# Altium schematic sheet 06_POWER_4.SchDoc(06_POWER_4)
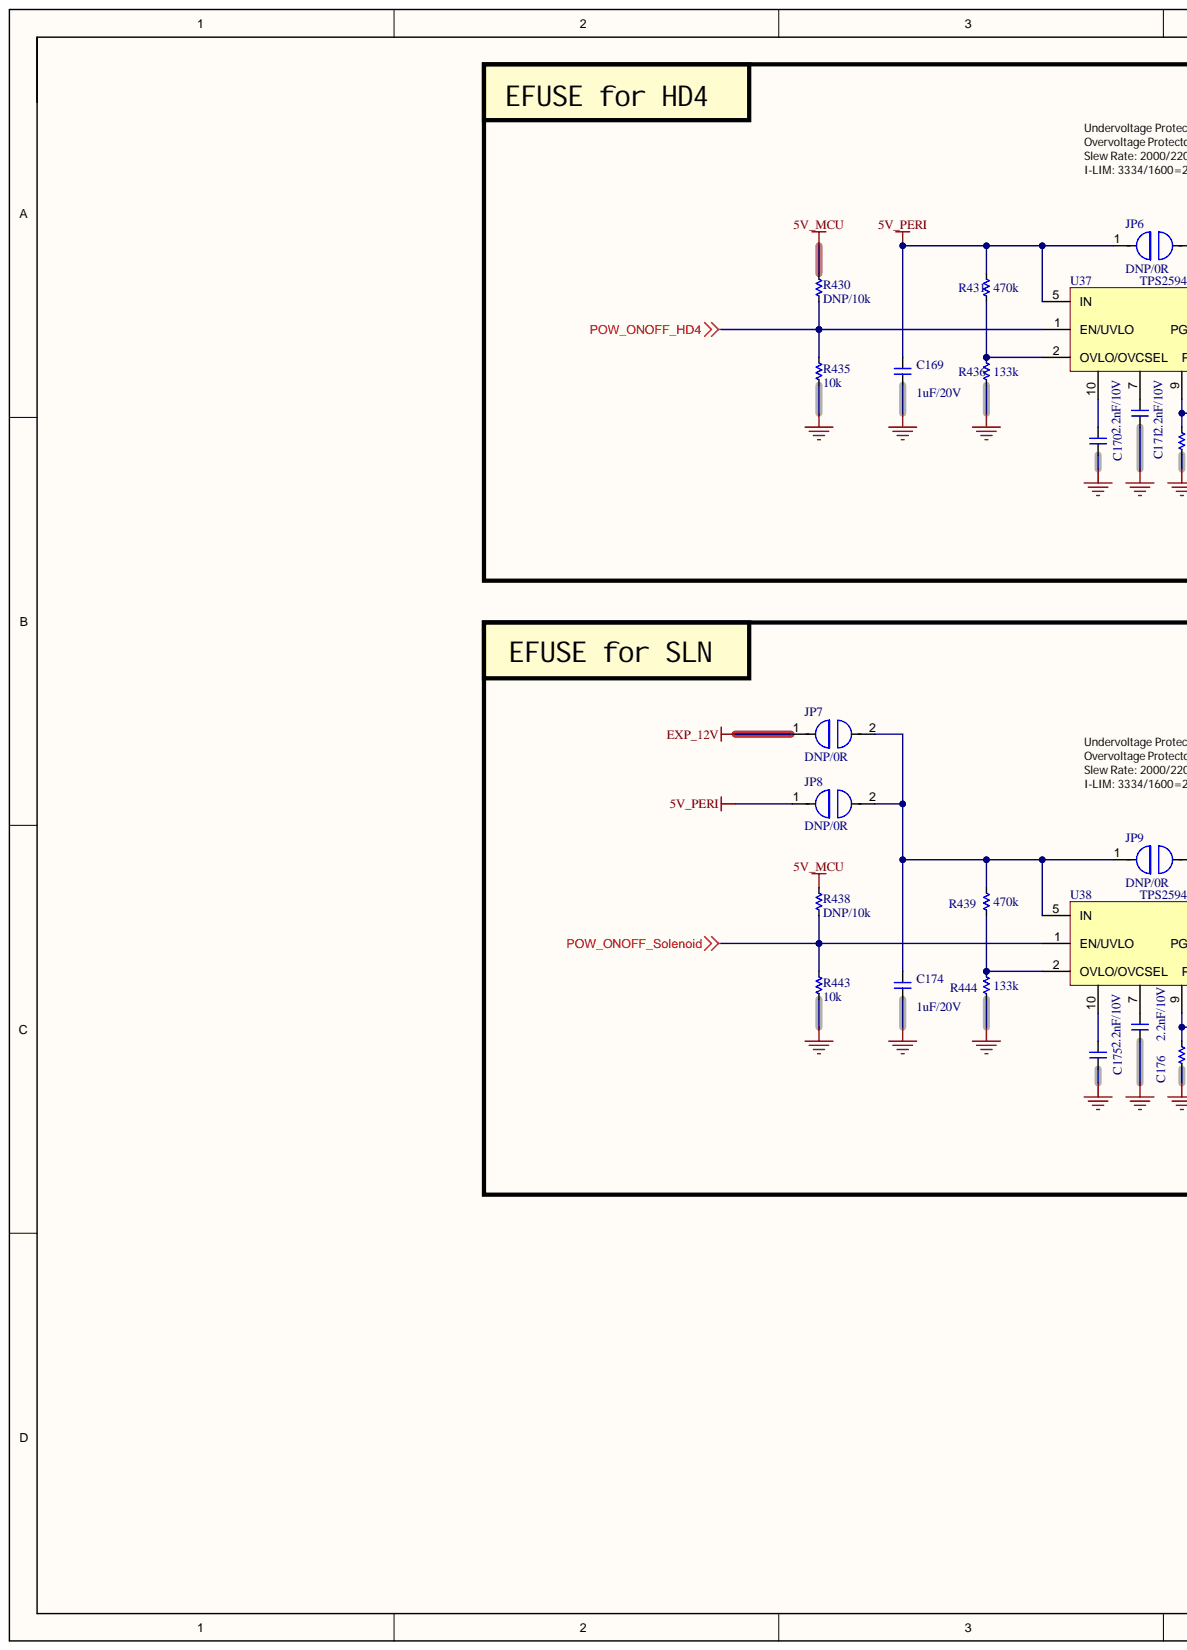
[diagram p0: 06_POWER_4.SchDoc, left]
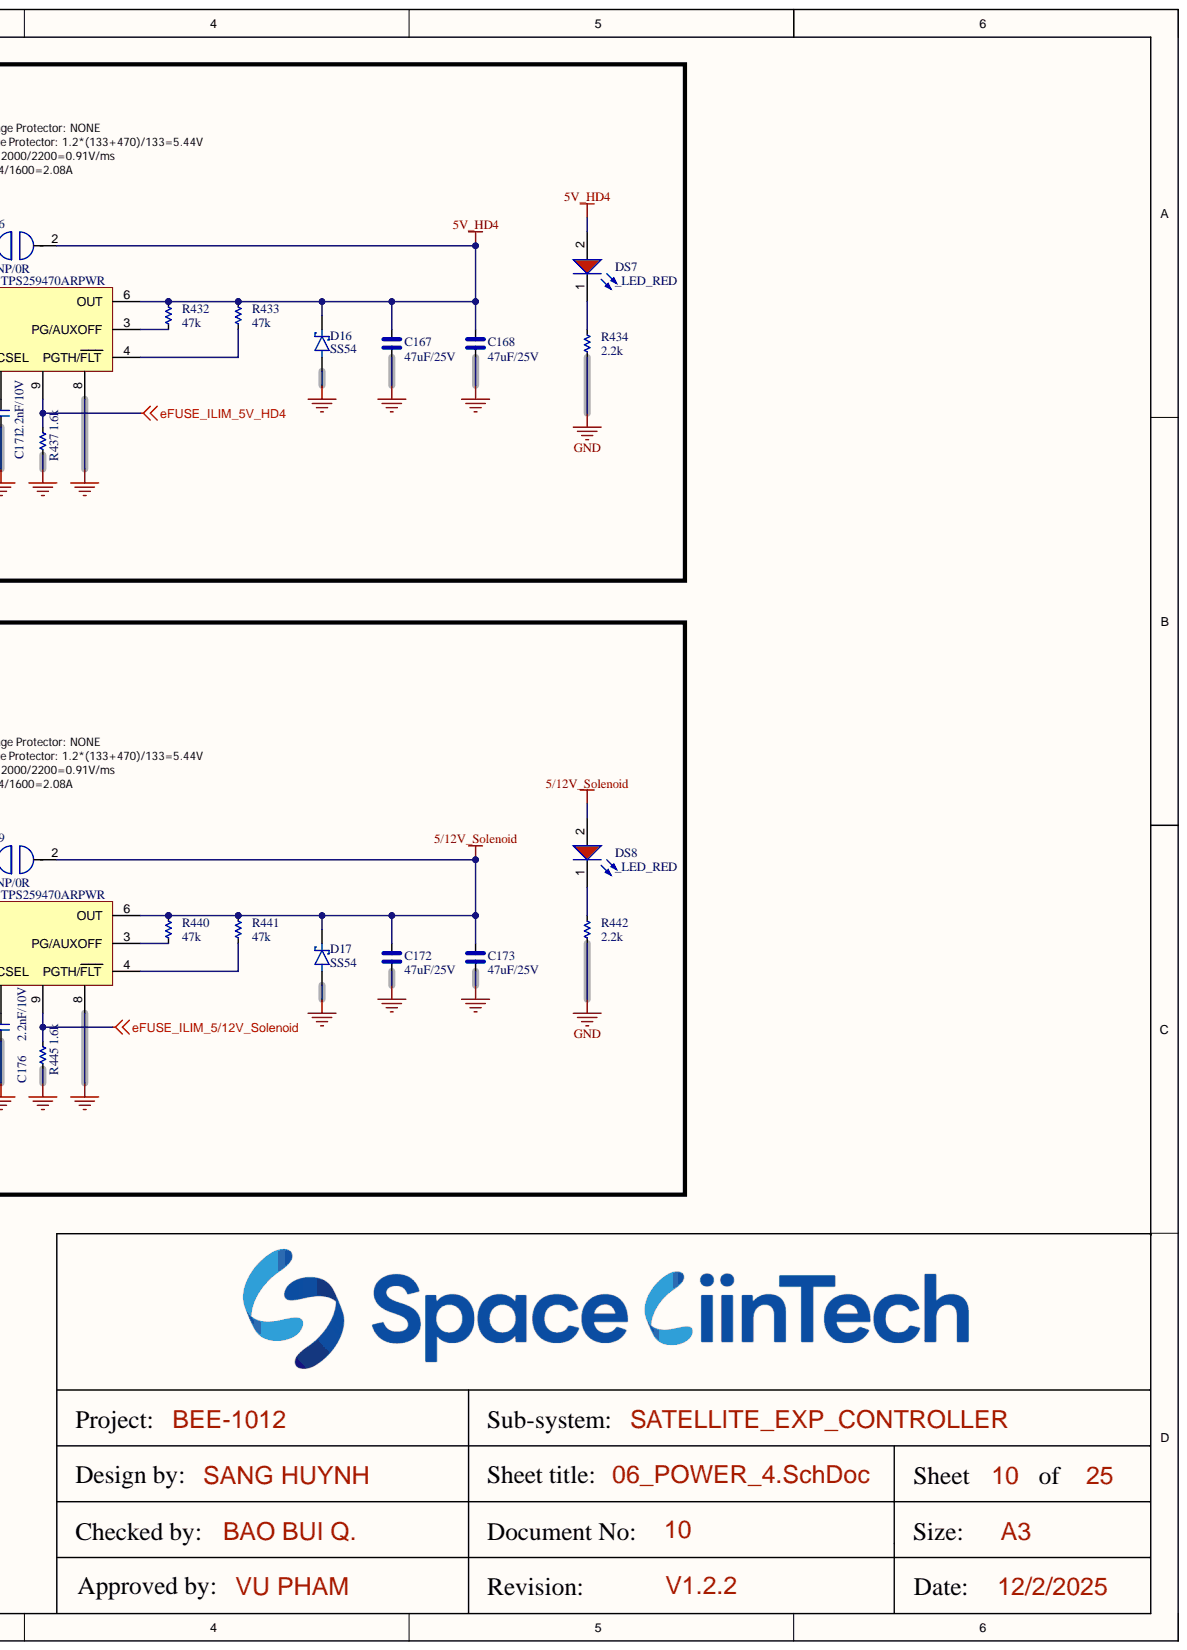
[diagram p1: 06_POWER_4.SchDoc, right]

## Parts
C167  47uF/25V  [CAP_C_3225X80]
C168  47uF/25V  [CAP_C_3225X80]
C169  1uF/20V  [CAP_C_1005X60]
C170  2.2nF/10V  [CAP_C_1005X60]
C171  2.2nF/10V  [CAP_C_1005X60]
C172  47uF/25V  [CAP_C_3225X80]
C173  47uF/25V  [CAP_C_3225X80]
C174  1uF/20V  [CAP_C_1005X60]
C175  2.2nF/10V  [CAP_C_1005X60]
C176  2.2nF/10V  [CAP_C_1005X60]
D16  SS54  [DO-214AC_SMA]
D17  SS54  [DO-214AC_SMA]
DS7  _LED_RED  [LED_0402]
DS8  _LED_RED  [LED_0402]
JP6  DNP/0R  [RES_C_1608X60]
JP7  DNP/0R  [RES_C_1608X60]
JP8  DNP/0R  [RES_C_1608X60]
JP9  DNP/0R  [RES_C_1608X60]
R430  DNP/10k  [RES_C_1005X40]
R431  470k  [RES_C_1005X40]
R432  47k  [RES_C_1005X40]
R433  47k  [RES_C_1005X40]
R434  2.2k  [RES_C_1005X40]
R435  10k  [RES_C_1005X40]
R436  133k  [RES_C_1005X40]
R437  1.6k  [RES_C_1005X40]
R438  DNP/10k  [RES_C_1005X40]
R439  470k  [RES_C_1005X40]
R440  47k  [RES_C_1005X40]
R441  47k  [RES_C_1005X40]
R442  2.2k  [RES_C_1005X40]
R443  10k  [RES_C_1005X40]
R444  133k  [RES_C_1005X40]
R445  1.6k  [RES_C_1005X40]
U37  TPS259470ARPWR  [VQFN-HR-10]
U38  TPS259470ARPWR  [VQFN-HR-10]

## Nets
5/12V_Solenoid: C172.2 C173.2 D17.1 DS8.2 JP9.2 R440.2 R441.2 U38.6(OUT)
5V_HD4: C167.2 C168.2 D16.1 DS7.2 JP6.2 R432.2 R433.2 U37.6(OUT)
5V_MCU: R430.1 R438.1
5V_PERI: C169.2 JP6.1 JP8.1 R431.2 U37.5(IN)
eFUSE_ILIM_5/12V_Solenoid: R445.2 U38.9
eFUSE_ILIM_5V_HD4: R437.2 U37.9
GND: C167.1 C168.1 C169.1 C170.1 C171.1 C172.1 C173.1 C174.1 C175.1 C176.1 D16.2 D17.2 R434.1 R435.2 R436.1 R437.1 R442.1 R443.2 R444.1 R445.1 U37.8 U38.8
POW_ONOFF_HD4: R430.2 R435.1 U37.1(EN/UVLO)
POW_ONOFF_Solenoid: R438.2 R443.1 U38.1(EN/UVLO)
NetC170_2: C170.2 U37.10
NetC171_2: C171.2 U37.7
NetC174_2: C174.2 JP7.2 JP8.2 JP9.1 R439.2 U38.5(IN)
NetC175_2: C175.2 U38.10
NetC176_2: C176.2 U38.7
NetDS7_1: DS7.1 R434.2
NetDS8_1: DS8.1 R442.2
NetR431_1: R431.1 R436.2 U37.2(OVLO/OVCSEL)
NetR432_1: R432.1 U37.3(PG/AUXOFF)
NetR433_1: R433.1 U37.4(PGTH/FLT)
NetR439_1: R439.1 R444.2 U38.2(OVLO/OVCSEL)
NetR440_1: R440.1 U38.3(PG/AUXOFF)
NetR441_1: R441.1 U38.4(PGTH/FLT)
EXP_12V:

Not connected: JP7 1

## Library data of the parts
C167 C168 C172 C173 = _CAP_C_High_2: CAP CHIP High Value; Component Kind: Standard; Library Name: LABLIB.SchLib
C169-C171 C174-C176 = _CAP_C_2: CAP CHIP; Component Kind: Standard; Library Name: LABLIB.SchLib
D16 D17 = DIODE SCHOTTKY: Component Kind: Standard; Description: DIODE SCHOTTKY; Library Name: LABLIB.SchLib
JP6-JP9 = JUMPER: Component Kind: Standard; Library Name: EXP_V1.SchLib
R430-R445 = _RES_B_2: RES; Component Kind: Standard; Library Name: LABLIB.SchLib
DS7 DS8 = _LED_RED: Component Kind: Standard; Library Name: LABLIB.SchLib
U37 U38 = TPS259470ARPWR: Component Kind: Standard; Library Name: EXP_HW_V3.SchLib
